# Altium schematic sheet USART.SchDoc(USART)
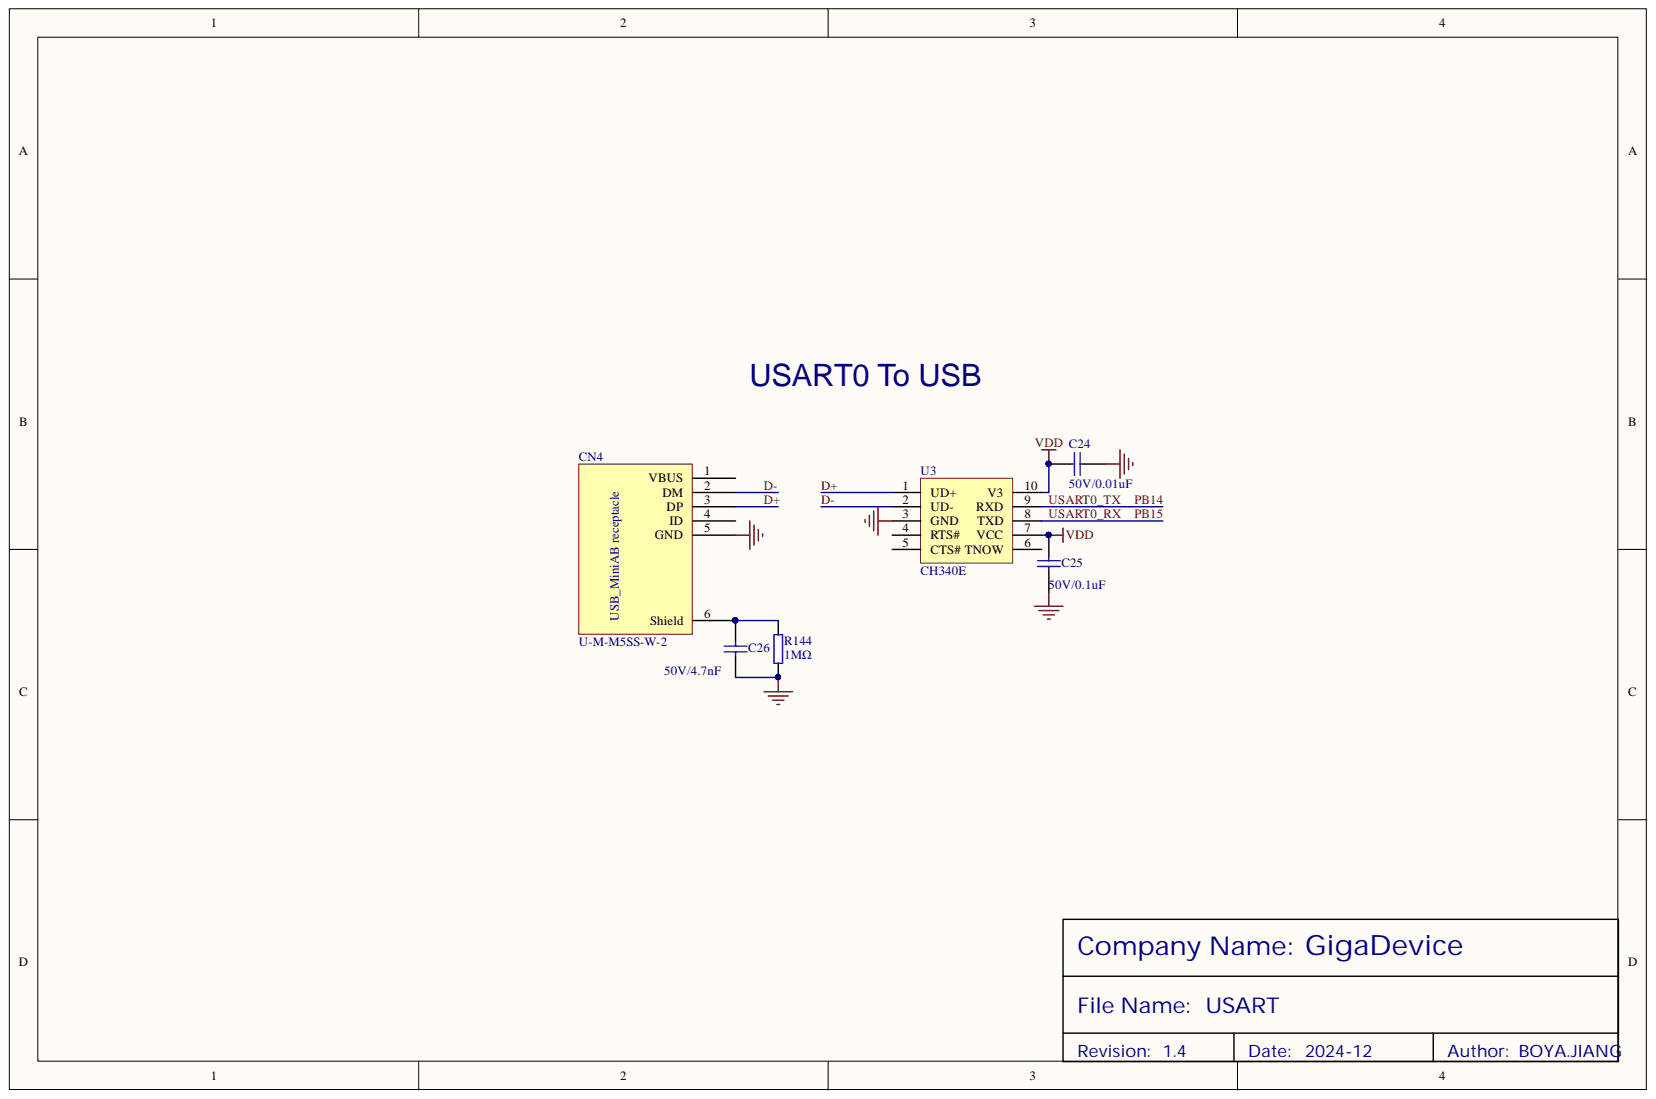
[diagram p0: USART.SchDoc]

## Parts
C24  50V/0.01uF  [0603-C]
C25  50V/0.1uF  [0603-C]
C26  50V/4.7nF  [0603-C]
CN4  U-M-M5SS-W-2  [USB_MINI]
R144  1MΩ  [0603-R]
U3  CH340E  [MSOP-10]

## Nets
GND: C24.1 C25.1 C26.1 CN4.5(GND) R144.1 U3.3(GND)
VDD: C24.2 C25.2 U3.7(VCC) U3.10(V3)
D+: CN4.3(DP) U3.1(UD+)
D-: CN4.2(DM) U3.2(UD-)
PB14: U3.9(RXD)
PB15: U3.8(TXD)
NetC26_2: C26.2 CN4.6(Shield) R144.2

Not connected: CN4 1(VBUS) 4(ID); U3 4(RTS#) 5(CTS#) 6(TNOW)

## Library data of the parts
C24 = 50V/0.01uF: 表贴电容; Component Kind: Standard; Library Name: KLTC-电容.SchLib
C25 = 50V/0.1uF: 表贴电容; Component Kind: Standard; Library Name: KLTC-电容.SchLib
C26 = 50V/4700pF: 表贴电容; Component Kind: Standard; Library Name: KLTC-电容.SchLib
CN4 = Mini_USB: 表贴miniusb座; Component Kind: Standard; Library Name: xufei.SchLib
R144 = 1MΩ: 表贴电阻; Component Kind: Standard; Library Name: KLTC-电阻.SchLib
U3 = CH340E: 表贴USART芯片; Library Name: Temp.SchLib; Component Kind: Standard
